# Altium schematic sheet Optical_transceiver.SchDoc(Optical_transceiver)
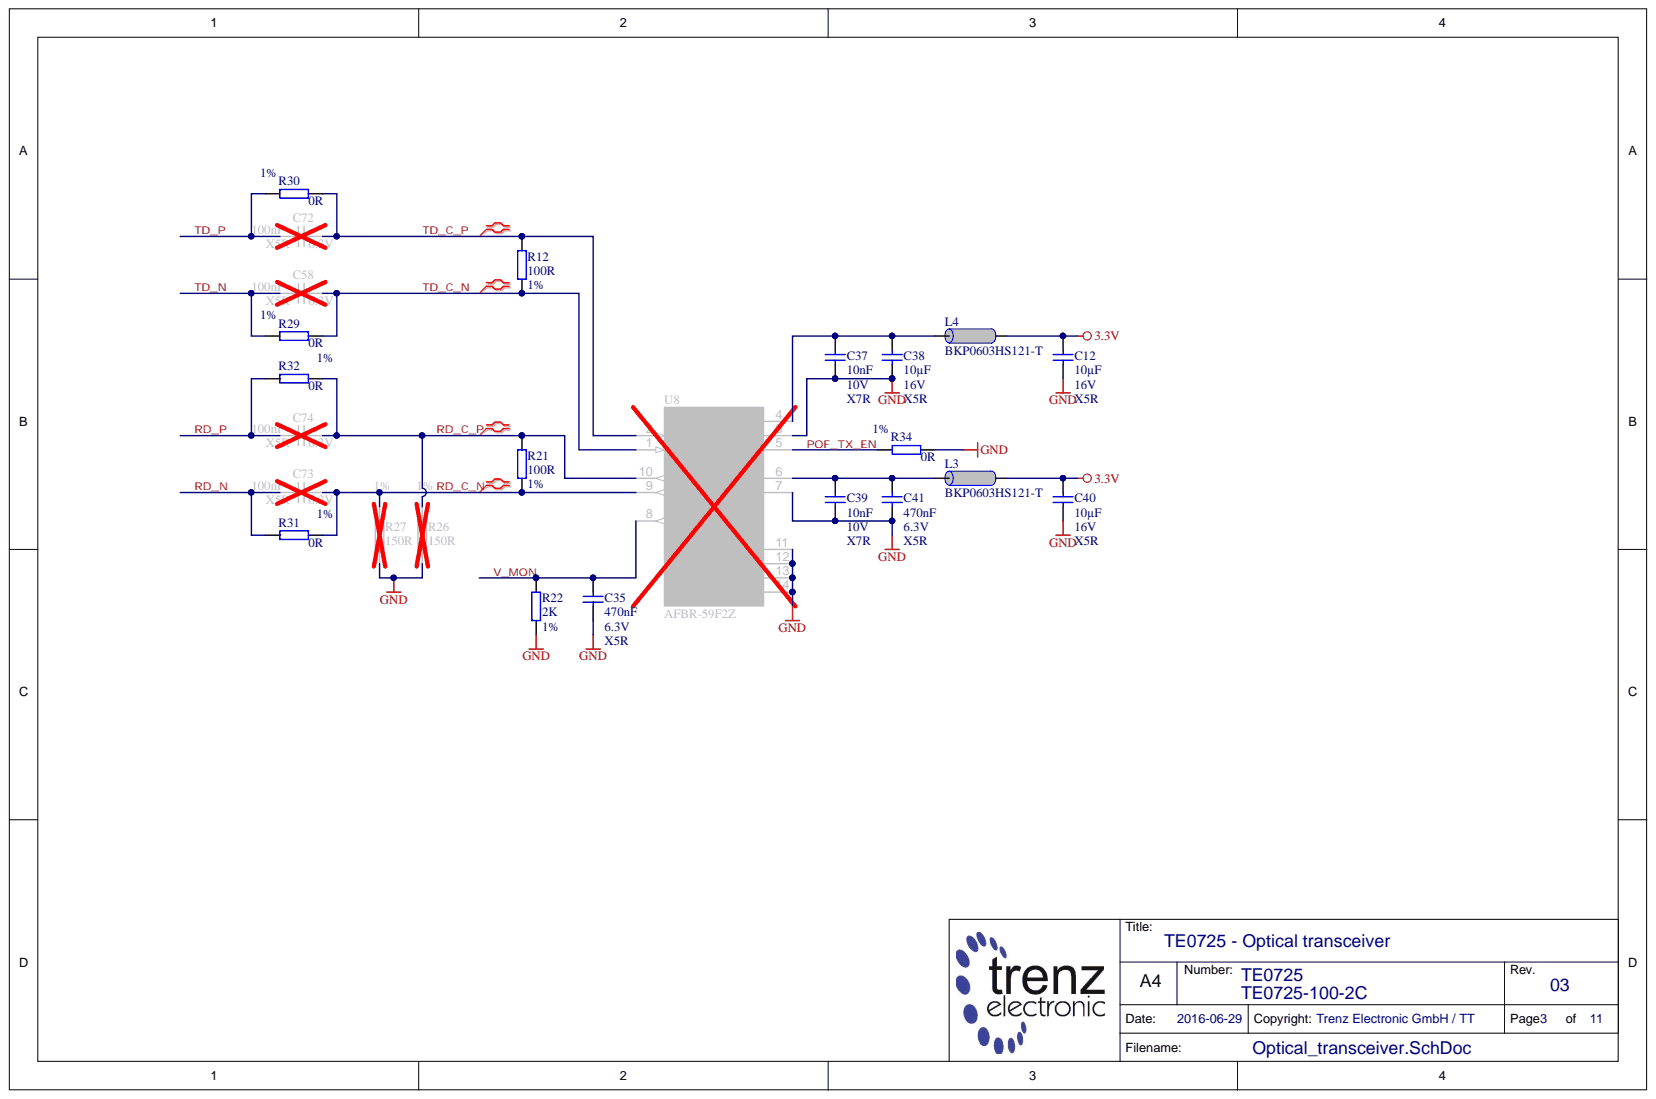
[diagram p0: Optical_transceiver.SchDoc]

## Parts
C12  10µF  Technology: X5R  Voltage: 16V  [CAPC1608X90N]
C35  470nF  Technology: X5R  Voltage: 6.3V  [CAPC0603X33N]
C37  10nF  Technology: X7R  Voltage: 10V  [CAPC0603X33N]
C38  10µF  Technology: X5R  Voltage: 16V  [CAPC1608X90N]
C39  10nF  Technology: X7R  Voltage: 10V  [CAPC0603X33N]
C40  10µF  Technology: X5R  Voltage: 16V  [CAPC1608X90N]
C41  470nF  Technology: X5R  Voltage: 6.3V  [CAPC0603X33N]
C58  100nF  Technology: X5R  Voltage: 6.3V  [CAPC0603X33N]
C72  100nF  Technology: X5R  Voltage: 6.3V  [CAPC0603X33N]
C73  100nF  Technology: X5R  Voltage: 6.3V  [CAPC0603X33N]
C74  100nF  Technology: X5R  Voltage: 6.3V  [CAPC0603X33N]
L3  BKP0603HS121-T  [INDC0603X33N]
L4  BKP0603HS121-T  [INDC0603X33N]
R12  100R  Tolerance: 1%  [RESC1005X40N]
R21  100R  Tolerance: 1%  [RESC1005X40N]
R22  2K  Tolerance: 1%  [RESC1005X40N]
R26  150R  Tolerance: 1%  [RESC1005X40N]
R27  150R  Tolerance: 1%  [RESC1005X40N]
R29  0R  Tolerance: 1%  [RESC0603X26N]
R30  0R  Tolerance: 1%  [RESC0603X26N]
R31  0R  Tolerance: 1%  [RESC0603X26N]
R32  0R  Tolerance: 1%  [RESC0603X26N]
R34  0R  Tolerance: 1%  [RESC0603X26N]
U8  AFBR-59F2Z  [AFBR-59F2Z]

## Nets
3.3V: C12.1 C40.1 L3.1 L4.1
GND: C12.2 C35.2 C37.2 C38.2 C39.2 C40.2 C41.2 R22.2 R26.2 R27.2 R34.2 U8.3(GND) U8.7(GND) U8.11(SHIELD) U8.12(SHIELD) U8.13(SHIELD) U8.14(SHIELD)
POF_TX_EN: R34.1 U8.5(GND)
RD_C_N: C73.2 R21.1 R27.1 R31.1 U8.9(RD_N)
RD_C_P: C74.2 R21.2 R26.1 R32.1 U8.10(RD_P)
RD_N: C73.1 R31.2
RD_P: C74.1 R32.2
TD_C_N: C58.2 R12.1 R29.2 U8.1(TD_N)
TD_C_P: C72.2 R12.2 R30.2 U8.2(TD_P)
TD_N: C58.1 R29.1
TD_P: C72.1 R30.1
V_MON: C35.1 R22.1 U8.8(MON)
NetC37_1: C37.1 C38.1 L4.2 U8.4(VDD)
NetC39_1: C39.1 C41.1 L3.2 U8.6(VDD)

## Library data of the parts
C12 C38 C40 = 25341: KON 10µF 16V X5R SMD 20%; Database Table Name: C_1608_0603; Mixed: SMT; Preferred: Yes; Value: 10u; AN: 25341; Case: 1608 (0603); Component Kind: Standard; Library Name: TE.DbLib; Tolerance: 20%
C35 C41 = 24921: KON 470nF X5R 6.3V SMD 20%; Database Table Name: C_0603_0201; Mixed: SMT; Preferred: Yes; Value: 470n; AN: 24921; Case: 0603 (0201); Component Kind: Standard; Library Name: TE.DbLib; Tolerance: 20%
C37 C39 = 26312: KON 10nF X7R 10V SMD 10%; Database Table Name: C_0603_0201; Mixed: SMT; Preferred: Yes; Value: 10n; AN: 26312; Case: 0603 (0201); Component Kind: Standard; Library Name: TE.DbLib; Tolerance: 10%
C58 C72-C74 = 24915: KON 100nF 6.3V X5R SMD 10%; Database Table Name: C_0603_0201; Mixed: SMT; Preferred: Yes; Value: 100n; AN: 24915; Case: 0603 (0201); Component Kind: Standard; Library Name: TE.DbLib; Tolerance: 10%
L3 L4 = 25393: Ferritdurchführung SMD 0201; Database Table Name: L_0603_0201; Mixed: SMT; Preferred: Yes; Tolerance: 25%; AN: 25393; Case: 0603 (0201); Component Kind: Standard; H-ART: BKP0603HS121-T; Library Name: TE.DbLib; Trenz-Stock: Yes; HelpURL: B:\AD\adlib\datasheet\MULTILAYER CHIP BEAD INDUCTORS BK
R12 R21 = 22507: WID SMD 100R 1% 0,063W; Database Table Name: R_1005_0402; Mixed: SMT; Preferred: Yes; Value: 100; AN: 22507; Case: 1005 (0402); Component Kind: Standard; Library Name: TE.DbLib; Technology: TK100
R22 = 25073: WID SMD 2K 1% 0,063W; Database Table Name: R_1005_0402; Mixed: SMT; AN: 25073; Case: 1005 (0402); Component Kind: Standard; Library Name: TE.DbLib; Technology: TK100; Value: 2K
R26 R27 = 22766: WID SMD 150R 1% 0,063W; Database Table Name: R_1005_0402; Mixed: SMT; Preferred: Yes; Value: 150; AN: 22766; Case: 1005 (0402); Component Kind: Standard; Library Name: TE.DbLib; Technology: TK100
R29-R32 R34 = 26259: WID SMD 0R 0,05W SMD; Database Table Name: R_0603_0201; Mixed: SMT; Preferred: Yes; Value: 0; AN: 26259; Case: 0603 (0201); Component Kind: Standard; Library Name: TE.DbLib; Technology: TK100; HelpURL: B:\AD\adlib\datasheet\R0201
U8 = 26735: Database Table Name: IF; Mixed: THT; AN: 26735; Component Kind: Standard; Description: AFBR-59F2Z; H-ART: AFBR-59F2Z; Library Name: TE.DbLib; HelpURL: B:\ad\adlib\datasheet\AFBR-59F2Z
